# Altium schematic sheet TUCH.SchDoc(TUCH)
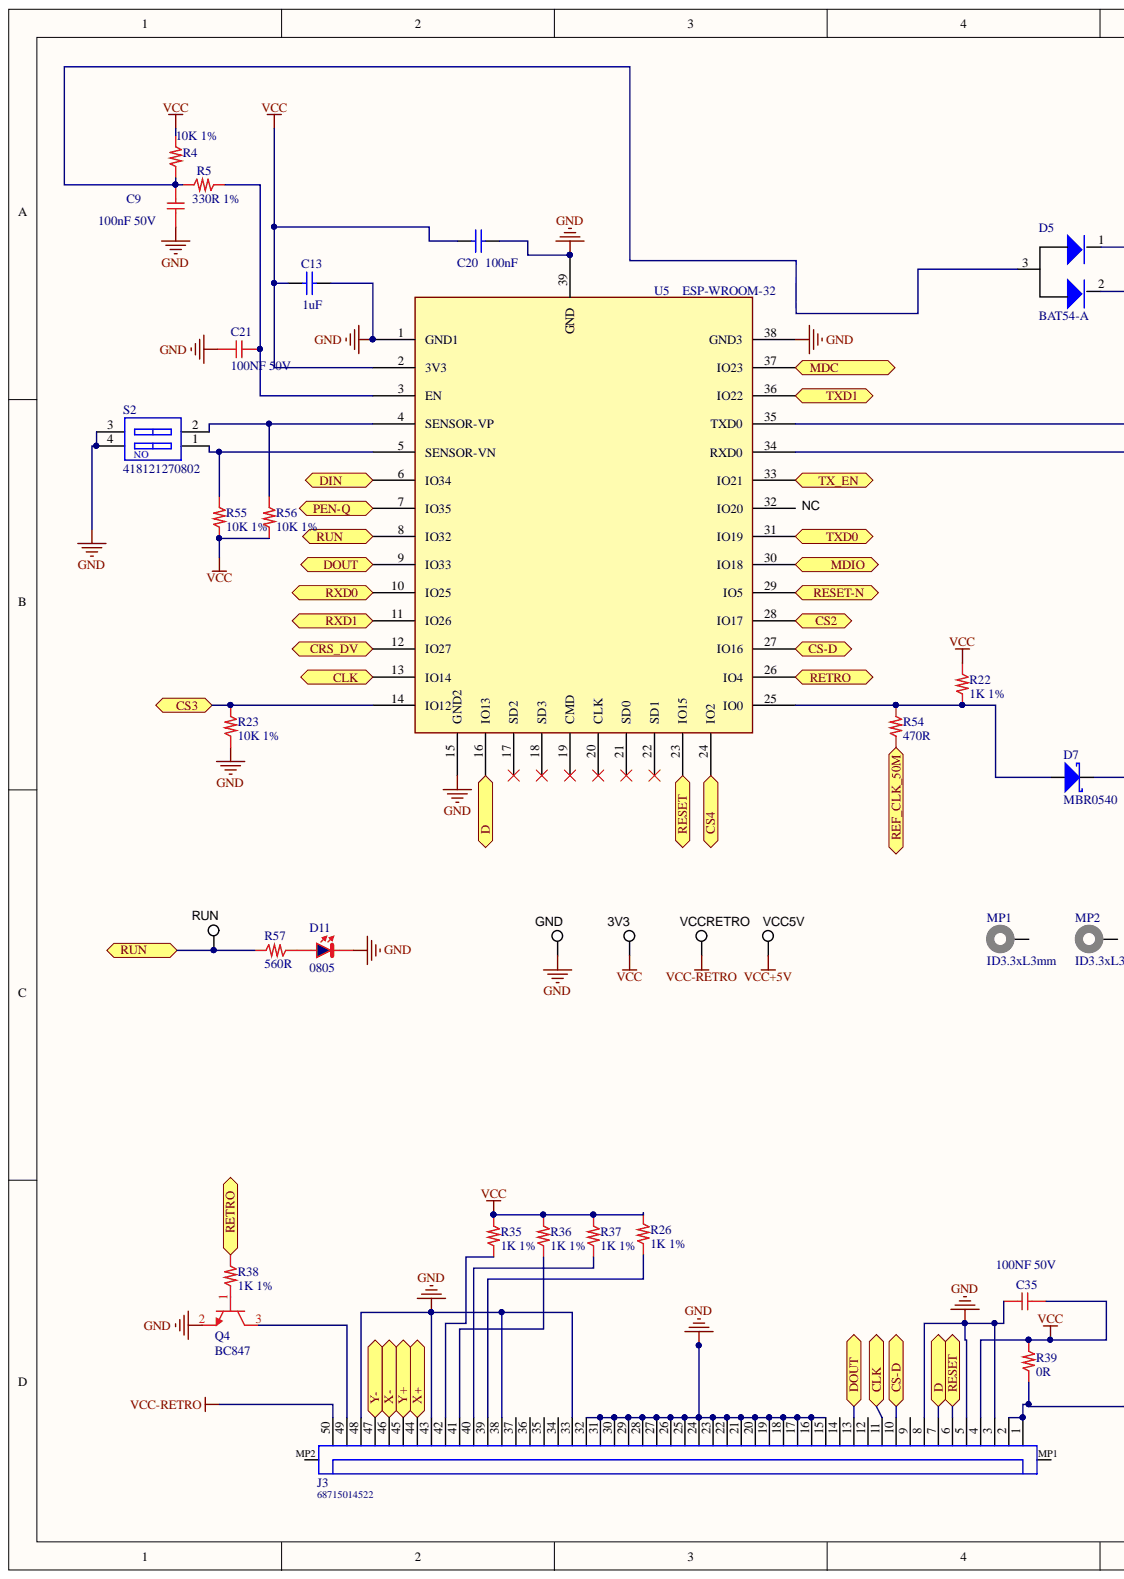
[diagram p0: TUCH.SchDoc, left]
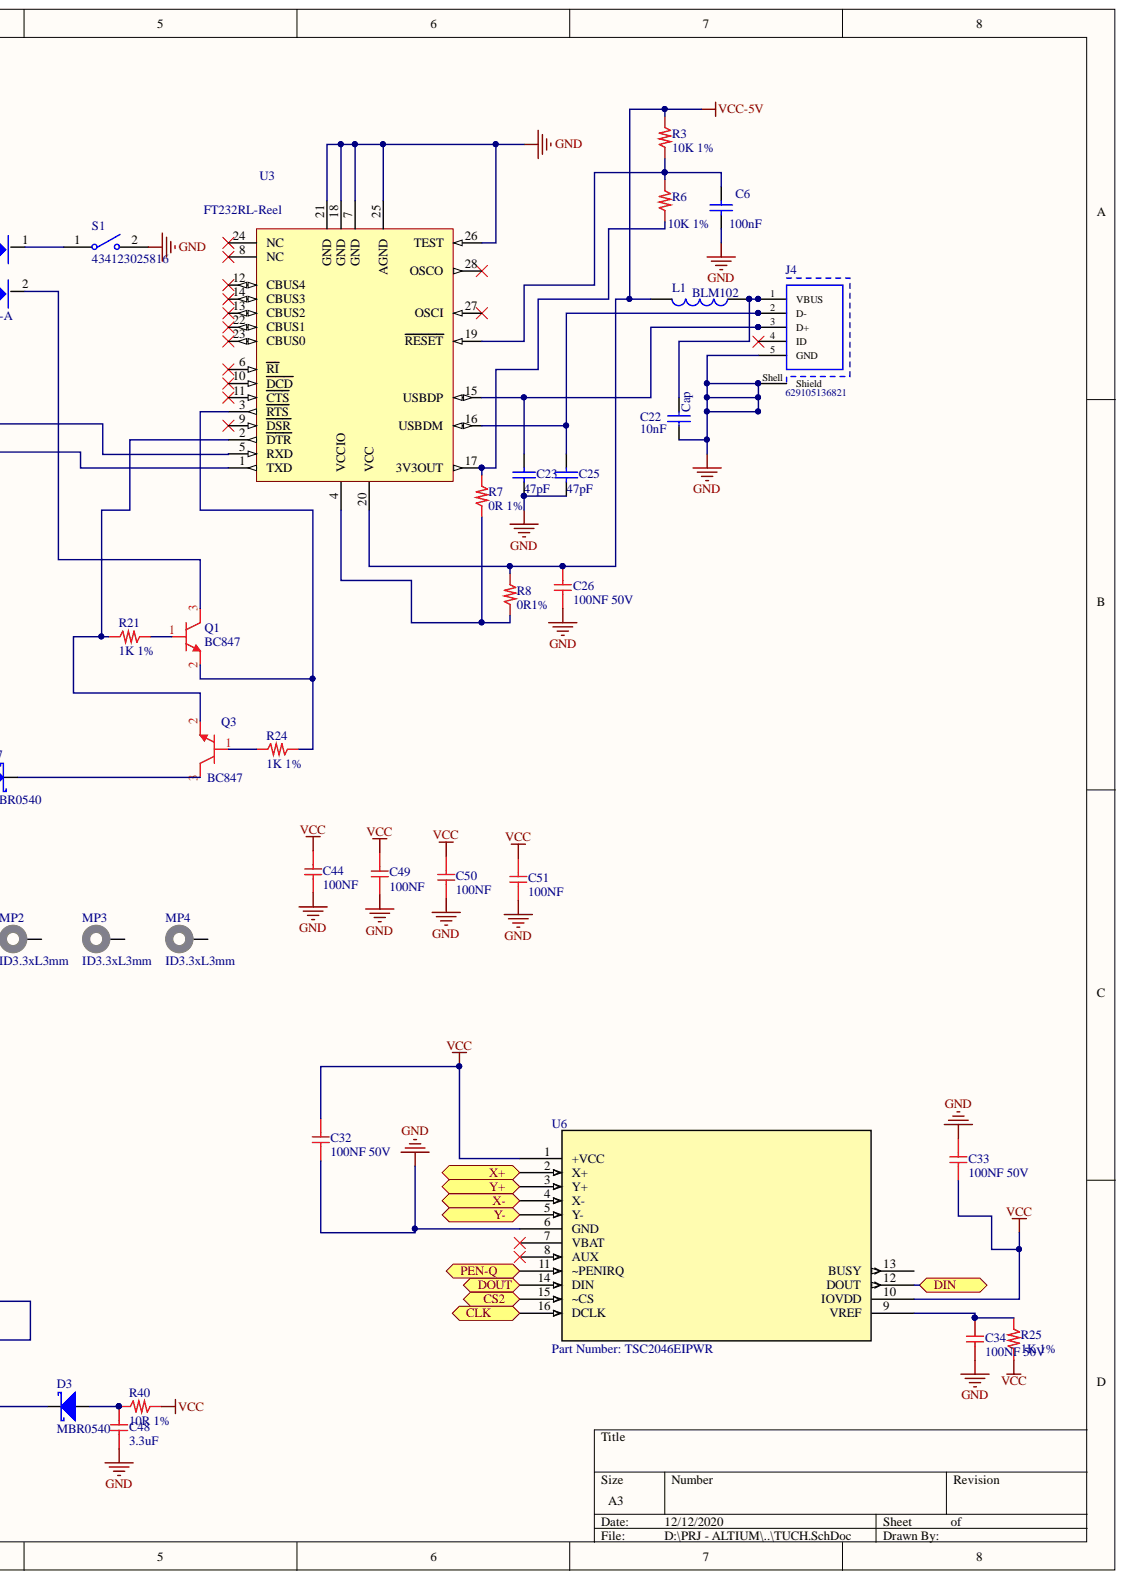
[diagram p1: TUCH.SchDoc, right]

## Parts
3V3  5012  [Keystone5012]  (value not drawn)
C6  value 100nF  [0603S_C]
C9  100nF 50V  [0603_c]
C13  value 1uF  [0603S_C]
C20  value 100nF  [0603S_C]
C21  100NF 50V  [0603_c]
C22  Cap  value 10nF  [0603S_C]
C23  value 47pF  [0603S_C]
C25  value 47pF  [0603S_C]
C26  100NF 50V  [0603_c]
C32  100NF 50V  [0603_c]
C33  100NF 50V  [0603_c]
C34  100NF 50V  [0603_c]
C35  100NF 50V  [0603_c]
C44  100NF  [0402_C]
C48  3.3uF  [0603_c]
C49  100NF  [0402_C]
C50  100NF  [0402_C]
C51  100NF  [0402_C]
D3  MBR0540  [SOD123]
D5  BAT54-A  [SOT23]
D7  MBR0540  [SOD123]
D11  0805  [0805-BLU]
GND  5012  [Keystone5012]  (value not drawn)
J3  68715014522  [68715014522]
J4  629105136821  [629105136821]
L1  BLM102  [WE-CBF_0805_Wide Band]
MP1  ID3.3xL3mm  [9774030960]
MP2  ID3.3xL3mm  [9774030960]
MP3  ID3.3xL3mm  [9774030960]
MP4  ID3.3xL3mm  [9774030960]
Q1  BC847  [SOT23]
Q3  BC847  [SOT23]
Q4  BC847  [SOT23]
R3  10K 1%  [0603_R]
R4  10K 1%  [0603_R]
R5  330R 1%  [0603_R]
R6  10K 1%  [0603_R]
R7  0R 1%  [0603_R]
R8  0R1%  [0603_R]
R21  1K 1%  [0603_R]
R22  1K 1%  [0603_R]
R23  10K 1%  [0603_R]
R24  1K 1%  [0603_R]
R25  1K 1%  [0603_R]
R26  1K 1%  [0603_R]
R35  1K 1%  [0603_R]
R36  1K 1%  [0603_R]
R37  1K 1%  [0603_R]
R38  1K 1%  [0603_R]
R39  0R  [0603_R]
R40  10R 1%  [1206_R]
R54  470R  [0603_R]
R55  10K 1%  [0603_R]
R56  10K 1%  [0603_R]
R57  560R  [0603_R]
RUN  5012  [Keystone5012]  (value not drawn)
S1  434123025816  [434123025816]
S2  418121270802  [418121270802]
U3  FT232RL-Reel  [SSOP-28_N]
U5  ESP-WROOM-32  [ESP32]
U6  Part Number: TSC2046EIPWR  [PW16]
VCC5V  5012  [Keystone5012]  (value not drawn)
VCCRETRO  5012  [Keystone5012]  (value not drawn)

## Ports
CLK, CRS_DV, CS2, CS3, CS4, CS-D, D, DIN, DOUT, MDC, MDIO, PEN-Q, REF_CLK_50M, RESET, RESET-N, RETRO, RUN, RXD0, RXD1, TX_EN, TXD0, TXD1, X+, X-, Y+, Y-

## Nets
GND: C6.1 C9.2 C13.2 C20.1 C21.1 C22.2 C23.1 C25.1 C26.2 C32.2 C33.1 C34.2 C35.1 C44.2 C48.1 C49.2 C50.2 C51.2 D11.K GND.1 J3.3 J3.5 J3.8 J3.15 J3.16 J3.17 J3.18 J3.19 J3.20 J3.21 J3.22 J3.23 J3.24 J3.25 J3.26 J3.27 J3.28 J3.29 J3.30 J3.31 J3.32 J3.33 J3.38 J3.43 J3.48 J4.5(GND) J4.Shell(Shield) Q4.2 R23.1 S1.2 S2.3 S2.4 U3.7(GND) U3.18(GND) U3.21(GND) U3.25(AGND) U3.26(TEST) U5.1(GND1) U5.15(GND2) U5.38(GND3) U5.39(GND) U6.6(GND)
VCC: 3V3.1 C13.1 C20.2 C32.1 C33.2 C35.2 C44.1 C49.1 C50.1 C51.1 J3.4 R4.2 R22.1 R25.2 R26.1 R35.1 R36.1 R37.1 R39.1 R40.1 R55.1 R56.1 U5.2(3V3) U6.1(+VCC) U6.10(IOVDD)
VCC+5V: VCC5V.1
VCC-5V: C26.1 L1.2 R3.2 R8.2 U3.20(VCC)
VCC-RETRO: J3.50 VCCRETRO.1
CLK: J3.11 U5.13(IO14) U6.16(DCLK) ; port CLK
CRS_DV: U5.12(IO27) ; port CRS_DV
CS2: U5.28(IO17) U6.15(~CS) ; port CS2
CS3: R23.2 U5.14(IO12) ; port CS3
CS4: U5.24(IO2) ; port CS4
CS-D: J3.10 U5.27(IO16) ; port CS-D
D: J3.7 U5.16(IO13) ; port D
DIN: U5.6(IO34) U6.12(DOUT) ; port DIN
DOUT: J3.13 U5.9(IO33) U6.14(DIN) ; port DOUT
MDC: U5.37(IO23) ; port MDC
MDIO: U5.30(IO18) ; port MDIO
PEN-Q: U5.7(IO35) U6.11(~PENIRQ) ; port PEN-Q
REF_CLK_50M: R54.2 ; port REF_CLK_50M
RESET: J3.6 U5.23(IO15) ; port RESET
RESET-N: U5.29(IO5) ; port RESET-N
RETRO: R38.2 U5.26(IO4) ; port RETRO
RUN: R57.1 RUN.1 U5.8(IO32) ; port RUN
RXD0: U5.10(IO25) ; port RXD0
RXD1: U5.11(IO26) ; port RXD1
TX_EN: U5.33(IO21) ; port TX_EN
TXD0: U5.31(IO19) ; port TXD0
TXD1: U5.36(IO22) ; port TXD1
NetC6_2: C6.2 R3.1 R6.2 U3.19(RESET)
NetC9_1: C9.1 D5.3 R4.1 R5.1
NetC21_2: C21.2 R5.2 U5.3(EN)
NetC22_1: C22.1 J4.1(VBUS) L1.1
NetC23_2: C23.2 J4.3(D+) U3.15(USBDP)
NetC25_2: C25.2 J4.2(D-) U3.16(USBDM)
NetC34_1: C34.1 R25.1 U6.9(VREF)
NetC48_2: C48.2 D3.1 R40.2
NetD3_2: D3.2 J3.1 J3.2 R39.2
NetD5_1: D5.1 S1.1
NetD5_2: D5.2 Q1.3
NetD7_A: D7.A R22.2 R54.1 U5.25(IO0)
NetD7_K: D7.K Q3.3
NetD11_A: D11.A R57.2
NetJ3_39: J3.39 R26.2
NetJ3_40: J3.40 R37.2
NetJ3_41: J3.41 R36.2
NetJ3_42: J3.42 R35.2
NetJ3_44: J3.44 U6.2(X+)
NetJ3_45: J3.45 U6.3(Y+)
NetJ3_46: J3.46 U6.4(X-)
NetJ3_47: J3.47 U6.5(Y-)
NetJ3_49: J3.49 Q4.3
NetQ1_1: Q1.1 R21.2
NetQ1_2: Q1.2 R24.2 U3.3(RTS)
NetQ3_1: Q3.1 R24.1
NetQ3_2: Q3.2 R21.1 U3.2(DTR)
NetQ4_1: Q4.1 R38.1
NetR6_1: R6.1 R7.2 U3.17(3V3OUT)
NetR7_1: R7.1 R8.1 U3.4(VCCIO)
NetR55_2: R55.2 S2.1 U5.5(SENSOR-VN)
NetR56_2: R56.2 S2.2 U5.4(SENSOR-VP)
NetU3_1: U3.1(TXD) U5.34(RXD0)
NetU3_5: U3.5(RXD) U5.35(TXD0)

Not connected: J3 9 12 14 34 35 36 37 MP1 MP2; J4 4(ID); MP1 1; MP2 1; MP3 1; MP4 1; U3 6(RI) 8(NC) 9(DSR) 10(DCD) 11(CTS) 12(CBUS4) 13(CBUS2) 14(CBUS3) 22(CBUS1) 23(CBUS0) 24(NC) 27(OSCI) 28(OSCO); U5 17(SD2) 18(SD3) 19(CMD) 20(CLK) 21(SD0) 22(SD1) 32(IO20); U6 7(VBAT) 8(AUX) 13(BUSY)

## Library data of the parts
3V3 GND RUN VCC5V VCCRETRO = TP: Test Point, Multipurpose, White, TH; Manufacturer: Keystone; ContactFinish: Silver; CompType: Test Point Loop; SymbolVersion: 2008-04-04; Supplier Part Number 2: 534-5012; Supplier Part Number 1: 36-5012-ND; Supplier 2: Mouser; Supplier 1: Digi-Key; RoHS: Y; PartNumber: 5012; PackageReference: White Multipurpose Testpoint; MountType: TH; LatestRevisionDate: 2017-01-19; ComponentLink1URL: http://www.keyelco.com/userAssets/file/M60-2p60.pdf; ComponentLink1Description: Datasheet URL; Component Kind: Standard; Color: White; Publisher: Texas Instruments; Library Name: HSW.SchLib
C6 C20 = Cap: CAPACITOR-X7R-100nF; Simulation: CAP; Published: 8-Jun-2000; PackageReference: RAD-0.3; PackageDescription: Radial Cap, Thru-Hole; 2 Leads; 0.3 in Pin Spacing; Library Name: Miscellaneous Devices.IntLib; LatestRevisionNote: Re-released for DXP Platform.; LatestRevisionDate: 17-Jul-2002; Component Kind: Standard; Publisher: Altium Limited
C9 C21 C26 C32-C35 = 100NF 50V: Cap X7R 100nF 50V Flex Terminal; Produttore: Samsung; Cod. Produttore: CL10B104KB8SFNC; Cod. Interno: COCS50665050104; Library Name: CapSMD0603.SchLib; Component Kind: Standard; Cod. DigiKey: 1276-1936-2-ND
C13 = Cap: CAP-X7R-1uF 25V; Simulation: CAP; Published: 8-Jun-2000; PackageReference: RAD-0.3; PackageDescription: Radial Cap, Thru-Hole; 2 Leads; 0.3 in Pin Spacing; Library Name: Miscellaneous Devices.IntLib; LatestRevisionNote: Re-released for DXP Platform.; LatestRevisionDate: 17-Jul-2002; Component Kind: Standard; Publisher: Altium Limited
C22 = Cap: Capacitor; Simulation: CAP; Published: 8-Jun-2000; PackageReference: RAD-0.3; PackageDescription: Radial Cap, Thru-Hole; 2 Leads; 0.3 in Pin Spacing; Library Name: Miscellaneous Devices.IntLib; LatestRevisionNote: Re-released for DXP Platform.; LatestRevisionDate: 17-Jul-2002; Component Kind: Standard; Publisher: Altium Limited
C23 C25 = Cap: CAPACITOR-X7R-47P; Simulation: CAP; Published: 8-Jun-2000; PackageReference: RAD-0.3; PackageDescription: Radial Cap, Thru-Hole; 2 Leads; 0.3 in Pin Spacing; Library Name: Miscellaneous Devices.IntLib; LatestRevisionNote: Re-released for DXP Platform.; LatestRevisionDate: 17-Jul-2002; Component Kind: Standard; Publisher: Altium Limited
C44 C49-C51 = 100NF 16V: Cap X7R 100NF 16V; Produttore: ??; Cod. Interno: COCS556561600104; Library Name: CapSMD0402.SchLib; Component Kind: Standard; Cod. Produttore: ??
C48 = 3.3uF 16V: 3.3µF, 16V, ±10%, X5R 0603; Produttore: TDK; Cod. Produttore: C1608X5R1C335K080AC; Cod. Interno: COCS??; Library Name: CapSMD0603.SchLib; Component Kind: Standard
D3 D7 = MBR0540: Schottky Diode; Simulation: SKYDIODE; Published: 8-Jun-2000; PackageReference: SMB; PackageDescription: DO-214-AA/SMB; 2 C-Bend Leads; Body 5.3 x 3.6 mm, inc. leads (LxW); LatestRevisionNote: Re-released for DXP Platform.; LatestRevisionDate: 17-Jul-2002; Component Kind: Standard; Code_JEDEC: DO-214-AA; Publisher: Altium Limited; Library Name: HSW.SchLib
D5 = BAT54-A: Diode Ultrafast Switching  SOT-23 BAT54-A; Supplier Part Number 1: BAV99FSTR-ND; Supplier 1: Digi-Key; Component Kind: Standard; Library Name: HSW.SchLib
D11 = LED BLU 0805: Vf(typ): 3.3V; Produttore: kingbright; Luminosità: 100mcd 20mA; Cod. Produttore: KPTR-3216QBC-D; Description: LED BLU 0805; Component Kind: Standard; Library Name: DiodiLED.SchLib
J3 = 68715014522: SMT ZIF Connectors WR-FPC, Horizontal, Bottom Contact, pitch 0.5 mm, 50 pins; Working Voltage: 50 VAC; Withstanding Voltage: 250 VAC; Rated Current: 0.5 A; Pitch: 0.5 mm; Pin Number: 50; Contact Resistance: 20 mOhm; Published Date: August 2018; Part Number: 68715014522; Packaging: Tape and Reel; Orientation: Horizontal; Operating Temperature: -25 to 85 degC; Mounting Technology: SMT; Library Name: ZIF Connectors WR-FPC_0.50 mm.SchLib; Contact Design: Bottom Contact; ComponentLink2URL: https://katalog.we-online.de/em/datasheet/68715014522.pdf; ComponentLink2Description: Datasheet; ComponentLink1URL: http://www.we-online.de; ComponentLink1Description: Manufacturer; Component Kind: Standard; Publisher: Wurth Elektronik
J4 = 629105136821: Micro USB 2.0 Type B Receptacle WR-COM, Horizontal, SMT, with Pads and Pegs; Working Voltage: 30 VAC; Rated Current: 1 A; Contact Resistance: 30 mOhm; Published Date: September 2018; Part Number: 629105136821; Packaging: Tape and Reel; Orientation: Horizontal; Operating Temperature: -40 to 105 degC; Mounting Technology: SMT; Library Name: USB Connectors WR-COM.SchLib; Gender: Receptacle; Connector Type: Micro USB 2.0 Type B; ComponentLink2URL: https://katalog.we-online.de/em/datasheet/629105136821.pdf; ComponentLink2Description: Datasheet; ComponentLink1URL: http://www.we-online.de; ComponentLink1Description: Manufacturer; Component Kind: Standard; Publisher: Wurth Elektronik
L1 = WE-CBF, 74279205: WE-CBF SMD EMI Suppression Ferrite Bead, 0805, 1000Ω; Type: Wide Band; Value: 1000Ω; Size: 0805; PublishedDate: Aug 2016; PartNumber: 74279205; Library Name: WE-CBF_0805.SchLib; ComponentLink2URL: http://katalog.we-online.de/pbs/datasheet/74279205.pdf; ComponentLink2Description: Datasheet; ComponentLink1URL: http://www.we-online.de; ComponentLink1Description: Manufacturer; Component Kind: Standard; Publisher: Wurth Elektronik
MP1-MP4 = 9774030960: WA-SMST SMT Steel Spacer with through hole, OD6mm, ID3.3xL3mm; Manufacturer: Wurth Elektronik; Surface: Tin; Outer Diameter: 6mm; Inner Diameter: 3.3mm; Published Date: May 2020; Packaging: Bulk; Operating Temperature: -55 to +150degC; Mounting Technology: SMT; Material: Steel; Manufacturer Part Number: 9774030960; Library Name: WA-SMST_ID3.3mm.SchLib; Length: 3mm; ComponentLink2URL: https://www.we-online.com/catalog/datasheet/9774030960.pdf; ComponentLink2Description: Datasheet; ComponentLink1URL: http://www.we-online.de; ComponentLink1Description: Manufacturer; Component Kind: Standard
Q1 Q3 Q4 = MMBT3904LT1G: NPN Si Digital Transistor; Vce: 40V; Vcb: 60V; Ptot: 300mW; Produttore: ON Semiconductor; Ic: 200mA; Ft: 300MHz; Cod. Interno: TRXS 110MMBT3904LT1G; Component Kind: Standard; Cod. Produttore: MMBT3904LT1G; Library Name: TransitorSMD.SCHLIB
R3-R8 R21-R26 R35-R38 R55 R56 = 1K 1%: Res Film Spesso 1/16W 1K 1%; Produttore: ??; Cod. Interno: RESS4166356B0102; Library Name: ResSMD0603.SchLib; Component Kind: Standard; Cod. Produttore: ??
R39 = 0R: Res Film Spesso 1/16W 0R 5%; Cod. Interno: RESS 4166556B0000; Library Name: ResSMD0603.SchLib; Component Kind: Standard
R40 = 10R 1%: 10R 1% 1206 1/4W; Produttore: Yageo; Cod. Produttore: RC1206FR-0710RL; Cod. Interno: RESS 4175325B0100; Library Name: ResSMD1206.SchLib; Component Kind: Standard; Cod. DigiKey: 311-10.0FRCT-ND; Case: 1206
R54 = 470R: Res Film Spesso 1/16W 470R 5%; Produttore: ??; Cod. Interno: RESS4166556B0471??; Library Name: ResSMD0603.SchLib; Component Kind: Standard; Cod. Produttore: ??
R57 = 560R: Res Film Spesso 1/16W 560R 5%; Cod. Interno: RESS 4166556B0561; Library Name: ResSMD0603.SchLib; Component Kind: Standard
S1 = 434123025816: WS-TASV J-Bend SMT Tact Switch 6x3.8mm, height 2.5mm, 160gf; Washable: No; Rated Voltage: 12VDC; Rated Current: 50mA; Terminal Plating: Silver; Terminal Material: Copper Alloy; Published Date: September 2019; Part Number: 434123025816; Packaging: Tape and Reel; Operation Force: 160gf; Operating Temperature: -40 to +85degC; Mounting Technology: SMT; Library Name: WS-TASV.SchLib; Height: 2.5mm; Contact Plating: Silver; Contact Material: Stainless Steel; ComponentLink2URL: https://katalog.we-online.com/em/datasheet/434123025816.pdf; ComponentLink2Description: Datasheet; ComponentLink1URL: http://www.we-online.de; ComponentLink1Description: Manufacturer; Component Kind: Standard; Publisher: Wurth Elektronik
S2 = 418121270802: SMD Dip Switch WS-DISV, Small Compact, Raised Actuator, pitch 2.54mm, 2pin; Pole Number: 2; Operation Force: 600g max.; Actuator Type: Raise Type; Published Date: April 2019; Pitch: 2.54mm; Part Number: 418121270802; Packaging: Tape and Reel; Operating Temperature: -40 to +85degC; Mounting Technology: SMT; ComponentLink2URL: https://katalog.we-online.com/em/datasheet/418121270802.pdf; ComponentLink2Description: Datasheet; ComponentLink1URL: http://www.we-online.de; ComponentLink1Description: Manufacturer; Component Kind: Standard; Publisher: Wurth Elektronik; Library Name: DIPSMD WS-DISV.SchLib
U3 = FT232RL-Reel: USB UART Asynchronous Serial Data Transfer Chip, SSOP-28, Tape and Reel; Published: 19-Aug-2010; PackageVersion: 2010; PackageReference: SSOP-28; PackageDescription: 28-Pin Small Outline Package 0.65 mm Pitch; DatasheetVersion: ver 2.07, Jul-2010; ComponentLink2URL: http://www.ftdichip.com/Support/Documents/DataSheets/ICs/DS_FT232R.pdf; ComponentLink2Description: Datasheet; ComponentLink1URL: http://www.ftdichip.com/Products/ICs/FT232R.htm; ComponentLink1Description: Manufacturer Link; Component Kind: Standard; Publisher: Altium Limited; Library Name: POLMAC-WIFI.SCHLIB
U5 = ESP32: Component Kind: Standard; Library Name: HSW.SchLib
U6 = TSC2046EIPWR: No Description Available; Vendor: Texas Instruments; Built_By: EMA_UL_Team; Value: Value; Type: DEV; RefDes: RefDes; Manufacturer_Part_Number: TSC2046EIPWR; Library Name: TSC2046.SchLib; Component Kind: Standard; Copyright: Copyright (C) 2018 Accelerated Designs. All rights reserved
